# Altium schematic sheet Sheet2.SchDoc(Sheet2)
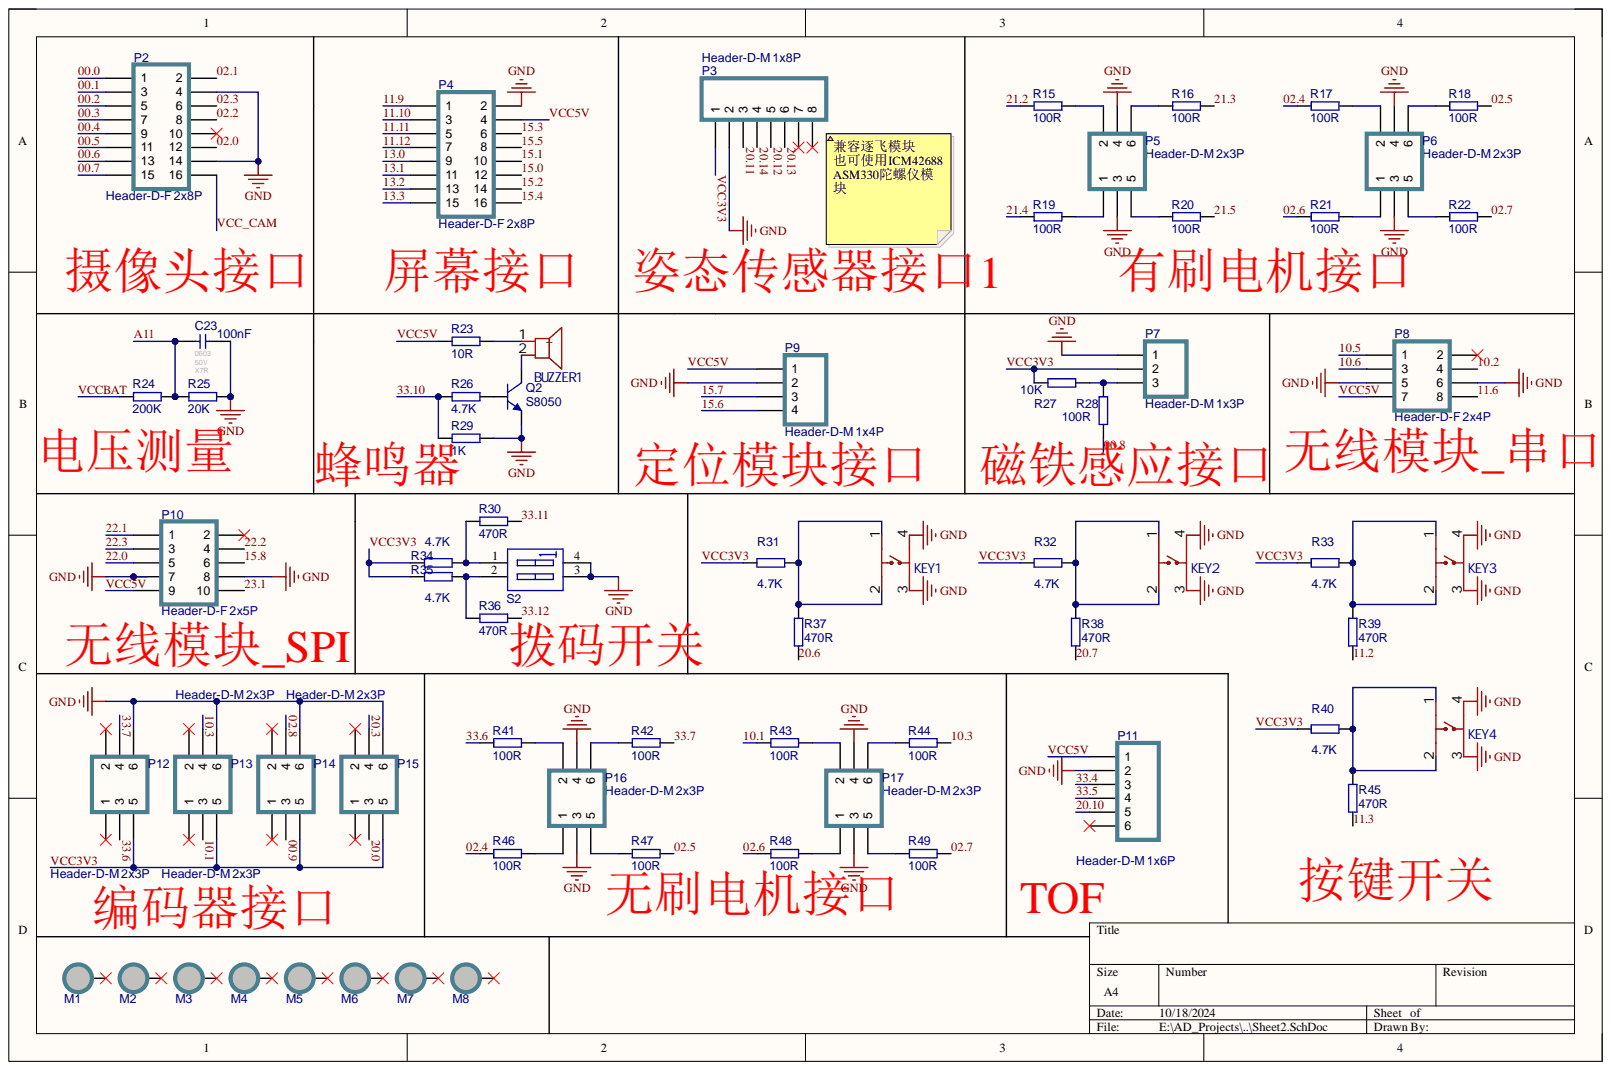
[diagram p0: Sheet2.SchDoc]

## Parts
BUZZER1  3kHz  [BUZ-TH_BD9.6-P5.00-D0.7-FD]  (value not drawn)
C23  100nF 50V X7R  value 100nF  Voltage: 50V  [0603]
KEY1  B3F-4055  [B3F-4055]  (value not drawn)
KEY2  B3F-4055  [B3F-4055]  (value not drawn)
KEY3  B3F-4055  [B3F-4055]  (value not drawn)
KEY4  B3F-4055  [B3F-4055]  (value not drawn)
M1  MountHole  [M3-MountHole-Plated-D6]  (value not drawn)  (no BOM)
M2  MountHole  [M3-MountHole-Plated-D6]  (value not drawn)  (no BOM)
M3  MountHole  [M3-MountHole-Plated-D6]  (value not drawn)  (no BOM)
M4  MountHole  [M3-MountHole-Plated-D6]  (value not drawn)  (no BOM)
M5  MountHole  [M3-MountHole-Plated-D6]  (value not drawn)  (no BOM)
M6  MountHole  [M3-MountHole-Plated-D6]  (value not drawn)  (no BOM)
M7  MountHole  [M3-MountHole-Plated-D6]  (value not drawn)  (no BOM)
M8  MountHole  [M3-MountHole-Plated-D6]  (value not drawn)  (no BOM)
P2  Header-D-F 2x8P  [HDR2X8-F]
P3  Header-D-M 1x8P  [HDR1X8-M]
P4  Header-D-F 2x8P  [HDR2X8-F]
P5  Header-D-M 2x3P  [HDR2X3-M]
P6  Header-D-M 2x3P  [HDR2X3-M]
P7  Header-D-M 1x3P  [HDR1X3-M]
P8  Header-D-F 2x4P  [HDR2X4-F]
P9  Header-D-M 1x4P  [HDR1X4-M]
P10  Header-D-F 2x5P  [HDR2X5-F]
P11  Header-D-M 1x6P  [HDR1X6-M]
P12  Header-D-M 2x3P  [HDR2X3-M]
P13  Header-D-M 2x3P  [HDR2X3-M]
P14  Header-D-M 2x3P  [HDR2X3-M]
P15  Header-D-M 2x3P  [HDR2X3-M]
P16  Header-D-M 2x3P  [HDR2X3-M]
P17  Header-D-M 2x3P  [HDR2X3-M]
Q2  S8050  [SOT-23]
R15  value 100R  [RESC1608[0603]S-N]  (hidden comment: 100R ±1%)
R16  value 100R  [RESC1608[0603]S-N]  (hidden comment: 100R ±1%)
R17  value 100R  [RESC1608[0603]S-N]  (hidden comment: 100R ±1%)
R18  value 100R  [RESC1608[0603]S-N]  (hidden comment: 100R ±1%)
R19  value 100R  [RESC1608[0603]S-N]  (hidden comment: 100R ±1%)
R20  value 100R  [RESC1608[0603]S-N]  (hidden comment: 100R ±1%)
R21  value 100R  [RESC1608[0603]S-N]  (hidden comment: 100R ±1%)
R22  value 100R  [RESC1608[0603]S-N]  (hidden comment: 100R ±1%)
R23  value 10R  [RESC1608[0603]S-N]  (hidden comment: 10R ±1%)
R24  value 200K  [RESC1608[0603]S-N]  (hidden comment: 200K ±1%)
R25  value 20K  [RESC1608[0603]S-N]  (hidden comment: 20K ±1%)
R26  value 4.7K  [RESC1608[0603]S-N]  (hidden comment: 4.7K ±1%)
R27  value 10K  [RESC1608[0603]S-N]  (hidden comment: 10K ±1%)
R28  value 100R  [RESC1608[0603]S-N]  (hidden comment: 100R ±1%)
R29  value 1K  [RESC1608[0603]S-N]  (hidden comment: 1K ±1%)
R30  value 470R  [RESC1608[0603]S-N]  (hidden comment: 470R ±1%)
R31  value 4.7K  [RESC1608[0603]S-N]  (hidden comment: 4.7K ±1%)
R32  value 4.7K  [RESC1608[0603]S-N]  (hidden comment: 4.7K ±1%)
R33  value 4.7K  [RESC1608[0603]S-N]  (hidden comment: 4.7K ±1%)
R34  value 4.7K  [RESC1608[0603]S-N]  (hidden comment: 4.7K ±1%)
R35  value 4.7K  [RESC1608[0603]S-N]  (hidden comment: 4.7K ±1%)
R36  value 470R  [RESC1608[0603]S-N]  (hidden comment: 470R ±1%)
R37  value 470R  [RESC1608[0603]S-N]  (hidden comment: 470R ±1%)
R38  value 470R  [RESC1608[0603]S-N]  (hidden comment: 470R ±1%)
R39  value 470R  [RESC1608[0603]S-N]  (hidden comment: 470R ±1%)
R40  value 4.7K  [RESC1608[0603]S-N]  (hidden comment: 4.7K ±1%)
R41  value 100R  [RESC1608[0603]S-N]  (hidden comment: 100R ±1%)
R42  value 100R  [RESC1608[0603]S-N]  (hidden comment: 100R ±1%)
R43  100R ±1%  value 100R  [RESC1608[0603]S-N]
R44  100R ±1%  value 100R  [RESC1608[0603]S-N]
R45  value 470R  [RESC1608[0603]S-N]  (hidden comment: 470R ±1%)
R46  value 100R  [RESC1608[0603]S-N]  (hidden comment: 100R ±1%)
R47  value 100R  [RESC1608[0603]S-N]  (hidden comment: 100R ±1%)
R48  value 100R  [RESC1608[0603]S-N]  (hidden comment: 100R ±1%)
R49  value 100R  [RESC1608[0603]S-N]  (hidden comment: 100R ±1%)
S2  SW DIP-2  [Code SW-2-DIP-2.54]  (value not drawn)

## Nets
GND: C23.2 KEY1.3 KEY1.4 KEY2.3 KEY2.4 KEY3.3 KEY3.4 KEY4.3 KEY4.4 P2.4 P2.14 P3.2 P4.2 P5.3 P5.4 P6.3 P6.4 P7.1 P8.5 P8.6 P9.2 P10.7 P10.8 P11.2 P12.6 P13.6 P14.6 P15.6 P16.3 P16.4 P17.3 P17.4 Q2.2 R25.2 R29.2 S2.3 S2.4
00.0: P2.1
00.1: P2.3
00.2: P2.5
00.3: P2.7
00.4: P2.9
00.5: P2.11
00.6: P2.13
00.7: P2.15
00.8: R28.1
00.9: P14.3
02.0: P2.12
02.1: P2.2
02.2: P2.8
02.3: P2.6
02.4: R17.1 R46.1
02.5: R18.2 R47.2
02.6: R21.1 R48.1
02.7: R22.2 R49.2
02.8: P14.4
10.1: P13.3 R43.1
10.2: P8.4
10.3: P13.4 R44.2
10.5: P8.1
10.6: P8.3
11.2: R39.1
11.3: R45.1
11.6: P8.8
11.9: P4.1
11.10: P4.3
11.11: P4.5
11.12: P4.7
13.0: P4.9
13.1: P4.11
13.2: P4.13
13.3: P4.15
15.0: P4.12
15.1: P4.10
15.2: P4.14
15.3: P4.6
15.4: P4.16
15.5: P4.8
15.6: P9.4
15.7: P9.3
15.8: P10.6
20.0: P15.3
20.3: P15.4
20.6: R37.1
20.7: R38.1
20.10: P11.5
20.11: P3.3
20.12: P3.5
20.13: P3.6
20.14: P3.4
21.2: R15.1
21.3: R16.2
21.4: R19.1
21.5: R20.2
22.0: P10.5
22.1: P10.1
22.2: P10.4
22.3: P10.3
23.1: P10.10
33.4: P11.3
33.5: P11.4
33.6: P12.3 R41.1
33.7: P12.4 R42.2
33.10: R26.1 R29.1
33.11: R30.2
33.12: R36.2
A11: C23.1 R24.2 R25.1
VCC3V3: P3.1 P7.2 P12.5 P13.5 P14.5 P15.5 R27.1 R31.1 R32.1 R33.1 R34.1 R35.1 R40.1
VCC5V: P4.4 P8.7 P9.1 P10.9 P11.1 R23.1
VCC_CAM: P2.16
VCCBAT: R24.1
NetBUZZER1_1: BUZZER1.1 R23.2
NetBUZZER1_2: BUZZER1.2 Q2.3
NetKEY1_1: KEY1.1 KEY1.2 R31.2 R37.2
NetKEY2_1: KEY2.1 KEY2.2 R32.2 R38.2
NetKEY3_1: KEY3.1 KEY3.2 R33.2 R39.2
NetKEY4_1: KEY4.1 KEY4.2 R40.2 R45.2
NetP5_1: P5.1 R19.2
NetP5_2: P5.2 R15.2
NetP5_5: P5.5 R20.1
NetP5_6: P5.6 R16.1
NetP6_1: P6.1 R21.2
NetP6_2: P6.2 R17.2
NetP6_5: P6.5 R22.1
NetP6_6: P6.6 R18.1
NetP7_3: P7.3 R27.2 R28.2
NetP16_1: P16.1 R46.2
NetP16_2: P16.2 R41.2
NetP16_5: P16.5 R47.1
NetP16_6: P16.6 R42.1
NetP17_1: P17.1 R48.2
NetP17_2: P17.2 R43.2
NetP17_5: P17.5 R49.1
NetP17_6: P17.6 R44.1
NetQ2_1: Q2.1 R26.2
NetR30_1: R30.1 R34.2 S2.1
NetR35_2: R35.2 R36.1 S2.2

Not connected: M1 1; M2 1; M3 1; M4 1; M5 1; M6 1; M7 1; M8 1; P2 10; P3 7 8; P8 2; P10 2; P11 6; P12 1 2; P13 1 2; P14 1 2; P15 1 2

## Library data of the parts
BUZZER1 = HNB09A05: 驱动方式:有源(内含驱动线路);构造类型:电磁式;频率:3kHz;声压(SPL):85dB;; Manufacturer: 华能; Add into BOM: yes; Operating Voltage: 4V~8V; Sound Pressure Level (SPL): 85dB; Technology Type: 电磁式; Component Kind: Standard; Convert to PCB: yes; Datasheet: https://atta.szlcsc.com/upload/public/pdf/source/20170522/1495448327549.pdf; Device: HNB09A05; Diameter (φD): 9mm; Height: 5.7mm; JLCPCB Part Class: 扩展库; LCSC Part Name: HNB09A05; Library Name: Tc2XX_MotherBoard.SCHLIB; Manufacturer Part: HNB09A05; Oscillator Circuit: 有源(内含驱动线路); PCB3D: BUZ-TH_D9.0-H5.5-P5.0; Supplier: LCSC; Supplier Footprint: 插件,D=9mm; Supplier Part: C96493; Symbol: HNB09A05; Value: 3kHz
C23 = 100nF 0603 50V X7R: 贴片瓷片电容; MLCC; 0603(1608);; Component Kind: Standard; Library Name: TC264_主板_V2.6.SCHLIB; Revision: V1.0; Type: X7R
KEY1-KEY4 = B3F-4055_C84931: 电路结构:单刀单掷;按钮形状:矩形按钮;安装方式:直插;开关长度:12mm;开关宽度:12mm;开关高度:7.3mm;触点电流:50mA;额定电压(DC):24V;带灯:-;机械寿命:-;; Manufacturer: OMRON(欧姆龙); Actuator Style: 矩形按钮; Add into BOM: yes; Circuit: 单刀单掷; Contact Current: 50mA; Switch Height: 7.3mm; Switch Length: 12mm; Voltage Rating (DC): 24V; Component Kind: Standard; Convert to PCB: yes; Datasheet: https://atta.szlcsc.com/upload/public/pdf/source/20170810/C93157_1502335414592880008.pdf; Device: B3F-4055_C84931; LCSC Part Name: 12*12*7.3mm 直插 轻触开关; Library Name: Tc2XX_MotherBoard.SCHLIB; Manufacturer Part: B3F-4055; Mechanical Life: -; Mounting Style: 直插; PCB3D: KEY-TH_4P-L12.0-W12.0-H7.5-LS12.5-P5.0; Supplier: LCSC; Supplier Footprint: 插件; Supplier Part: C84931; Switch Width: 12mm; Symbol: B3F-4055; With Lamp: -
M1-M8 = MountHole: 固定孔; Library Name: TC264_主板_V2.6.SCHLIB
P2 P4 = Header-D-F 2x8P: 2x8pin, 直插, 双排座; Component Kind: Standard; Library Name: TC264_主板_V2.6.SCHLIB; Revision: V1.0; Value: Header-D-F 2x8P
P3 = Header-D-M 1x8P: 1x8pin, 直插, 排针; Component Kind: Standard; Library Name: TC264_主板_V2.6.SCHLIB; Revision: V1.0; Value: Header-D-M 1x8P
P5 P6 P12-P17 = Header-D-M 2x3P: 2x3pin, 直插, 双排针; Component Kind: Standard; Library Name: TC264_主板_V2.6.SCHLIB; Revision: V1.0; Value: Header-D-M 2x3P
P7 = Header-D-M 1x3P: 1x3pin, 直插, 排针; Component Kind: Standard; Library Name: TC264_主板_V2.6.SCHLIB; Revision: V1.0; Value: Header-D-M 1x3P
P8 = Header-D-F 2x4P: 2x4pin, 直插, 双排座; Component Kind: Standard; Library Name: TC264_主板_V2.6.SCHLIB; Revision: V1.0; Value: Header-D-F 2x4P
P9 = Header-D-M 1x4P: 1x4pin, 直插, 排针; Component Kind: Standard; Library Name: TC264_主板_V2.6.SCHLIB; Revision: V1.0; Value: Header-D-M 1x4P
P10 = Header-D-F 2x5P: 2x5pin, 直插, 双排座; Component Kind: Standard; Library Name: TC264_主板_V2.6.SCHLIB; Revision: V1.0; Value: Header-D-F 2x5P
P11 = Header-D-M 1x6P: 1x6pin, 直插, 排针; Component Kind: Standard; Library Name: TC264_主板_V2.6.SCHLIB; Revision: V1.0; Value: Header-D-M 1x6P
Q2 = NPN S8050: NPN 三极管; Component Kind: Standard; Library Name: TC264_主板_V2.6.SCHLIB; PartNumber: S8050; Price(RMB): 0.000; Published: 8-Jun-2000; Revision: July-2002: Re-released for DXP Platform.; Publisher: Altium Limited
R15-R22 R28 R41-R44 R46-R49 = Res-Chip_9: 贴片电阻; Power: 1/8W; Component Kind: Standard; Library Name: TC264_主板_V2.6.SCHLIB; Revision: V1.0; Tolerance: ±1%
R23 = Res-Chip_10: 贴片电阻; Power: 1/8W; Component Kind: Standard; Library Name: TC264_主板_V2.6.SCHLIB; Revision: V1.0; Tolerance: ±1%
R24 = Res-Chip_1: 贴片电阻; Power: 1/8W; Component Kind: Standard; Library Name: TC264_主板_V2.6.SCHLIB; Revision: V1.0; Tolerance: ±1%
R25 = Res-Chip_4: 贴片电阻; Power: 1/8W; Component Kind: Standard; Library Name: TC264_主板_V2.6.SCHLIB; Revision: V1.0; Tolerance: ±1%
R26 R31-R35 R40 = Res-Chip_3: 贴片电阻; Power: 1/8W; Component Kind: Standard; Library Name: TC264_主板_V2.6.SCHLIB; Revision: V1.0; Tolerance: ±1%
R27 = Res-Chip: 贴片电阻; Power: 1/8W; Component Kind: Standard; Library Name: TC264_主板_V2.6.SCHLIB; Revision: V1.0; Tolerance: ±1%
R29 = Res-Chip_11: 贴片电阻; Power: 1/8W; Component Kind: Standard; Library Name: TC264_主板_V2.6.SCHLIB; Revision: V1.0; Tolerance: ±1%
R30 R36-R39 R45 = Res-Chip_12: 贴片电阻; Power: 1/8W; Component Kind: Standard; Library Name: TC264_主板_V2.6.SCHLIB; Revision: V1.0; Tolerance: ±1%
S2 = Code SW-2: 2位拨码开关; Component Kind: Standard; Library Name: TC264_主板_V2.6.SCHLIB; Price(RMB): 0.000; Published: 24-Mar-1999; Revision: July-2002: Re-released for DXP Platform.; Publisher: Altium Limited
